# Altium schematic sheet MCU_兼容4IO_HVAC.SchDoc(MCU_兼容4IO_HVAC)
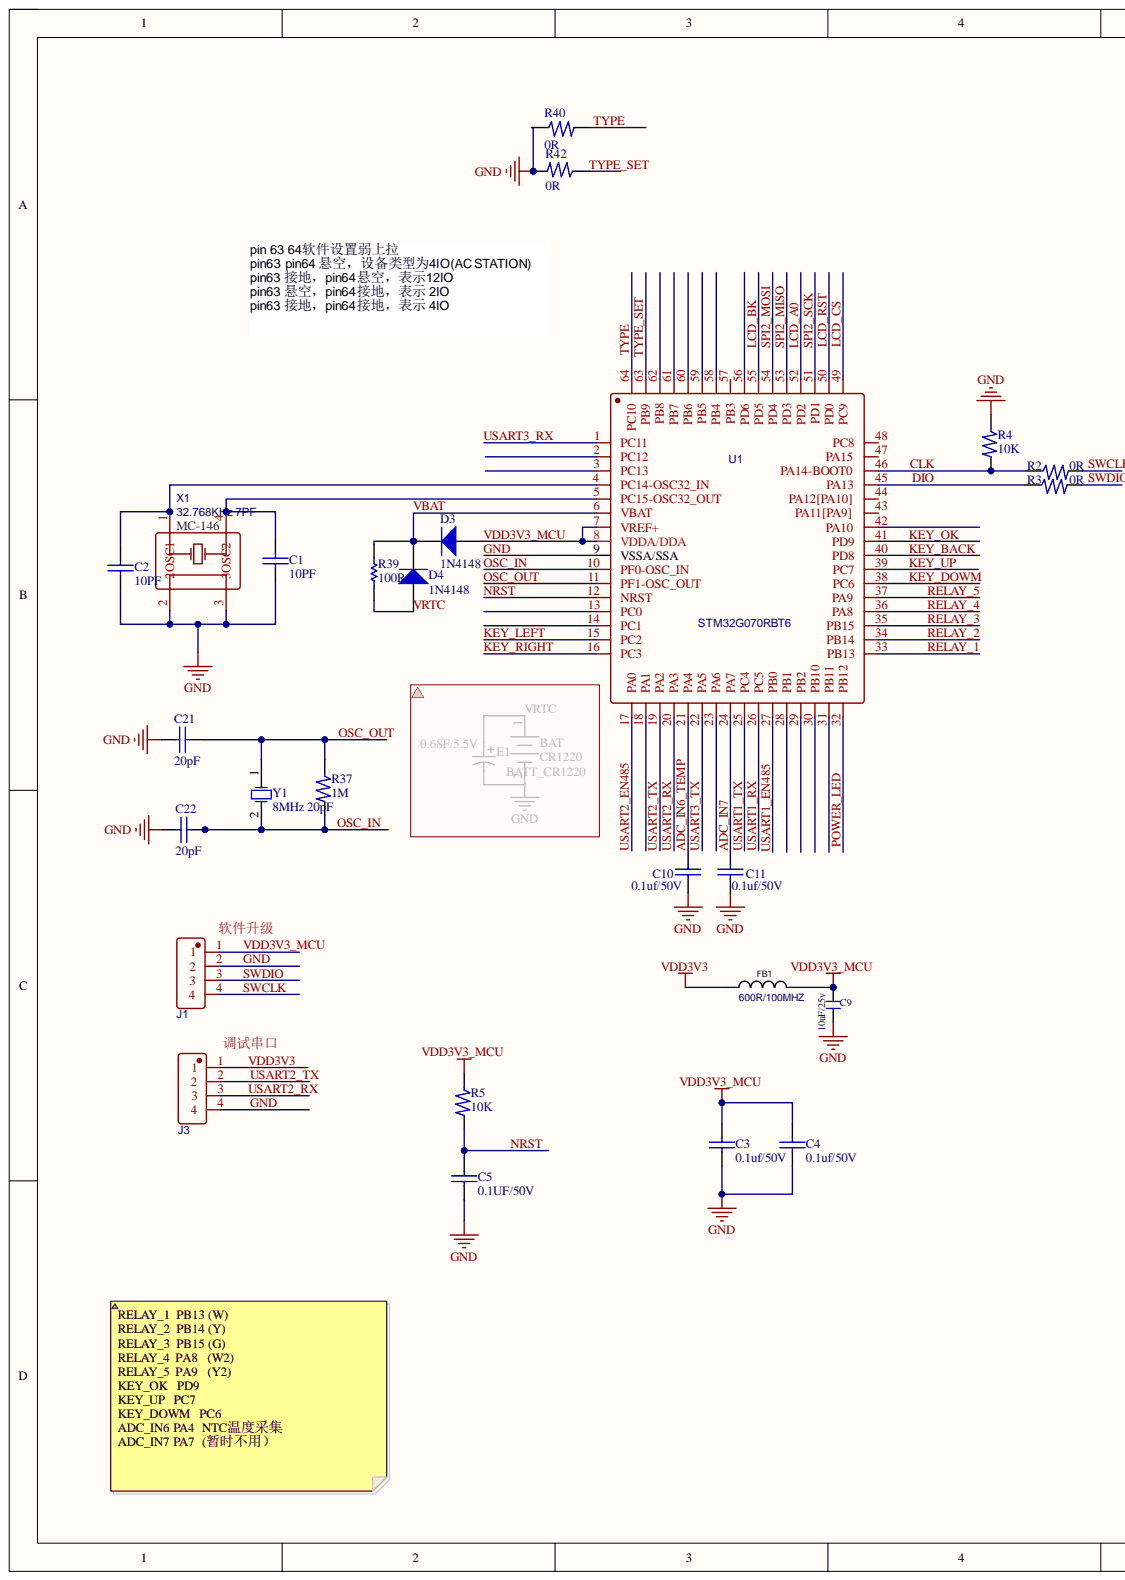
[diagram p0: MCU_兼容4IO_HVAC.SchDoc, left]
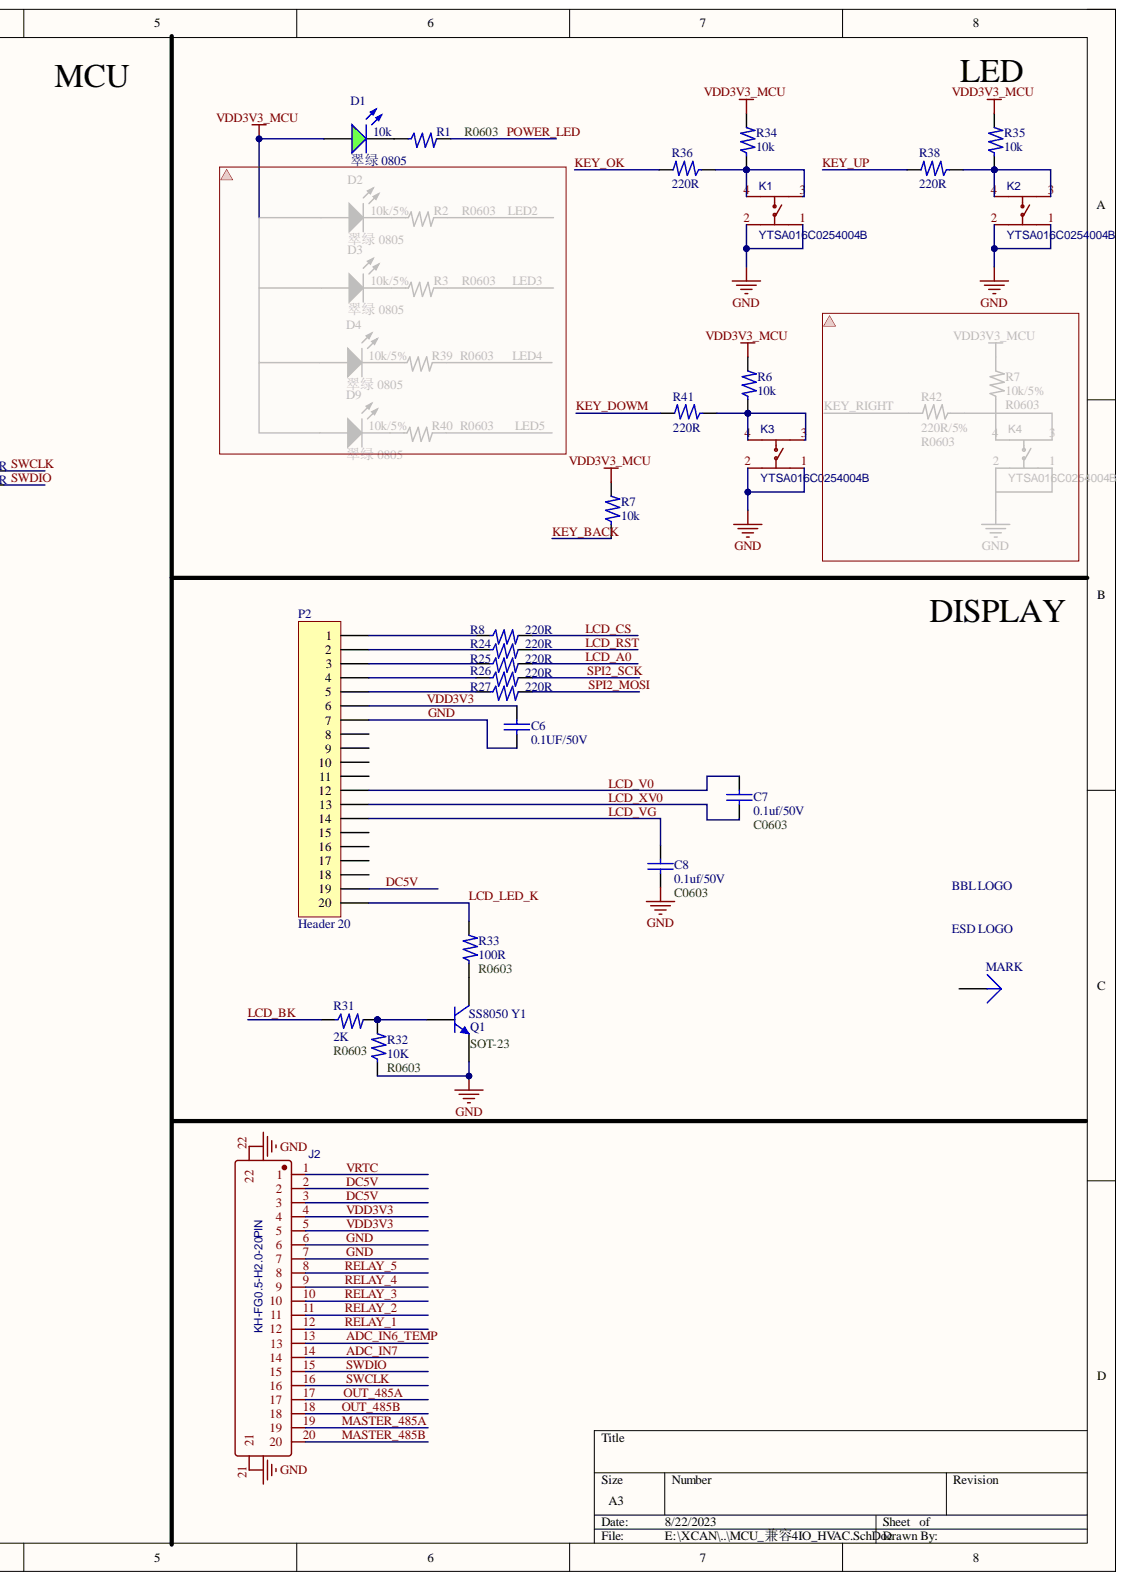
[diagram p1: MCU_兼容4IO_HVAC.SchDoc, right]

## Parts
BBL_LOGO  (no value)  [BBL LOGO]
C1  10PF  [0603_C]
C2  10PF  [0603_C]
C3  0.1uf/50V  [0603_C]
C4  0.1uf/50V  [0603_C]
C5  0.1UF/50V  [0603_C]
C6  0.1UF/50V  [0603_C]
C7  0.1uf/50V  [C0603]
C8  0.1uf/50V  [C0603]
C9  10uF/25v  [0603_c]
C10  0.1uf/50V  [0603_C]
C11  0.1uf/50V  [0603_C]
C21  20pF  [0603_C]
C22  20pF  [0603_C]
D1  翠绿 0805  Package: 0805  [0805_LED_S1]
D3  1N4148  [sod-323]
D4  1N4148  [sod-323]
ESD_LOGO  (no value)  [防静电LOGO]
FB1  600R/100MHZ  [L0603]
J1  Header 4  [CON4-2.0]  (value not drawn)
J2  KH-FG0.5-H2.0-20PIN  [FPC-SMD_KH-FG0.5-H2.0-20PIN]
J3  4PIN2.54  [4PIN2.54]  (value not drawn)
K1  YTSA016C0254004B  [KEY-SMD_4P-L4.2-W3.4-P2.15-LS4.5-TL]
K2  YTSA016C0254004B  [KEY-SMD_4P-L4.2-W3.4-P2.15-LS4.5-TL]
K3  YTSA016C0254004B  [KEY-SMD_4P-L4.2-W3.4-P2.15-LS4.5-TL]
MARK  Plug  [TOP_MARK]  (value not drawn)
P2  Header 20  [LCD1]
Q1  SS8050 Y1  Package: SOT-23  [SOT-23(SOT-23-3)]
R1  10k  [R0603]
R2  0R  [R0603]
R3  0R  [R0603]
R4  10K  [0603_R]
R5  10K  [0603_R]
R6  10k  [0603_R]
R7  10k  [0603_R]
R8  220R  [0603_R]
R24  220R  [0603_R]
R25  220R  [0603_R]
R26  220R  [0603_R]
R27  220R  [0603_R]
R31  2K  [R0603]
R32  10K  [R0603]
R33  100R  [R0603]
R34  10k  [0603_R]
R35  10k  [0603_R]
R36  220R  [0603_R]
R37  1M  [0603_R]
R38  220R  [0603_R]
R39  100R  [0603_R]
R40  0R  [R0603]
R41  220R  [0603_R]
R42  0R  [R0603]
U1  STM32G070RBT6  [LQFP-64_10x10x05P]
X1  32.768KHz 7PF  [MC-146_4P]
Y1  8MHz 20pF  [SMD5032-2P]

## Nets
ADC_IN6_TEMP: C10.1 J2.13 U1.21(PA4)
ADC_IN7: C11.1 J2.14 U1.24(PA7)
CLK: R2.2 R4.1 U1.46(PA14-BOOT0)
DC5V: J2.2 J2.3 P2.19
DIO: R3.2 U1.45(PA13)
GND: C1.2 C2.2 C3.2 C4.2 C5.1 C6.1 C8.2 C9.1 C10.2 C11.2 C21.2 C22.2 J1.2 J2.6 J2.7 J2.21 J2.22 J3.4 K1.1 K1.2 K2.1 K2.2 K3.1 K3.2 P2.7 Q1.2 R4.2 R32.2 R40.2 R42.1 U1.9(VSSA/SSA) X1.2 X1.3
KEY_BACK: R7.2 U1.40(PD8)
KEY_DOWM: R41.1 U1.38(PC6)
KEY_LEFT: U1.15(PC2)
KEY_OK: R36.1 U1.41(PD9)
KEY_RIGHT: U1.16(PC3)
KEY_UP: R38.1 U1.39(PC7)
LCD_A0: R25.1 U1.52(PD2)
LCD_BK: R31.2 U1.55(PD5)
LCD_CS: R8.1 U1.49(PC9)
LCD_LED_K: P2.20 R33.1
LCD_RST: R24.1 U1.50(PD0)
LCD_V0: C7.1 P2.12
LCD_VG: C8.1 P2.14
LCD_XV0: C7.2 P2.13
MASTER_485A: J2.19
MASTER_485B: J2.20
NRST: C5.2 R5.1 U1.12(NRST)
OSC_IN: C22.1 R37.1 U1.10(PF0-OSC_IN) Y1.2
OSC_OUT: C21.1 R37.2 U1.11(PF1-OSC_OUT) Y1.1
OUT_485A: J2.17
OUT_485B: J2.18
POWER_LED: R1.1 U1.32(PB12)
RELAY_1: J2.12 U1.33(PB13)
RELAY_2: J2.11 U1.34(PB14)
RELAY_3: J2.10 U1.35(PB15)
RELAY_4: J2.9 U1.36(PA8)
RELAY_5: J2.8 U1.37(PA9)
SPI2_MISO: U1.53(PD3)
SPI2_MOSI: R27.1 U1.54(PD4)
SPI2_SCK: R26.1 U1.51(PD1)
SWCLK: J1.4 J2.16 R2.1
SWDIO: J1.3 J2.15 R3.1
TYPE: R40.1 U1.64(PC10)
TYPE_SET: R42.2 U1.63(PB9)
USART1_EN485: U1.27(PB0)
USART1_RX: U1.26(PC5)
USART1_TX: U1.25(PC4)
USART2_EN485: U1.17(PA0)
USART2_RX: J3.3 U1.20(PA3)
USART2_TX: J3.2 U1.19(PA2)
USART3_RX: U1.1(PC11)
USART3_TX: U1.22(PA5)
VBAT: D3.2 D4.2 R39.2 U1.6(VBAT)
VDD3V3: C6.2 FB1.1 J2.4 J2.5 J3.1 P2.6
VDD3V3_MCU: C3.1 C4.1 C9.2 D3.1 FB1.2 J1.1 R5.2 R6.1 R7.1 R34.1 R35.1 U1.7(VREF+) U1.8(VDDA/DDA)
VRTC: D4.1 J2.1 R39.1
NetC1_1: C1.1 U1.5(PC15-OSC32_OUT) X1.4(OSC2)
NetC2_1: C2.1 U1.4(PC14-OSC32_IN) X1.1(OSC1)
NetD1_K: D1.K R1.2
NetK1_3: K1.3 K1.4 R34.2 R36.2
NetK2_3: K2.3 K2.4 R35.2 R38.2
NetK3_3: K3.3 K3.4 R6.2 R41.2
NetP2_1: P2.1 R8.2
NetP2_2: P2.2 R24.2
NetP2_3: P2.3 R25.2
NetP2_4: P2.4 R26.2
NetP2_5: P2.5 R27.2
NetQ1_1: Q1.1 R31.1 R32.1
NetQ1_3: Q1.3 R33.2

Not connected: D1 A; MARK 1; P2 8 9 10 11 15 16 17 18; U1 2(PC12) 3(PC13) 13(PC0) 14(PC1) 18(PA1) 23(PA6) 28(PB1) 29(PB2) 30(PB10) 31(PB11) 42(PA10) 43(PA11[PA9]) 44(PA12[PA10]) 47(PA15) 48(PC8) 56(PD6) 57(PB3) 58(PB4) 59(PB5) 60(PB6) 61(PB7) 62(PB8)

## Library data of the parts
BBL_LOGO ESD_LOGO = Component_1: Component Kind: Standard; Library Name: 元件库.SCHLIB
C1-C8 C10 C11 C21 C22 = CAP: Capacitor; Component Kind: Standard
C9 = C: 无极性贴片电容; 版权归属: 源创客; BOM名称: 无极性贴片电容; Component Kind: Standard; Library Name: 3.1   - 电阻-电容-电感.SchLib; 学习资源: www.ooocke.com
D1 = 0805 翠绿: 发光二极管; Component Kind: Standard; ComponentLink1Description: 供应商链接; ComponentLink1URL: http://www.szlcsc.com/product/details_2670.html; Library Name: Miscellaneous Devices LC.IntLib; Supplier: LC; SuppliersPartNumber: C2297
D3 D4 = Diode 1N4148: High Conductance Fast Diode; Code_JEDEC: DO-204-AH; Component Kind: Standard; ComponentLink1Description: Manufacturer Link; ComponentLink1URL: http://www.fairchildsemi.com/; ComponentLink2Description: Datasheet; ComponentLink2URL: http://www.fairchildsemi.com/ds/1N%2F1N4148.pdf; DatasheetVersion: 1997; LatestRevisionDate: 17-Jul-2002; LatestRevisionNote: Re-released for DXP Platform.; Library Name: Miscellaneous Devices.IntLib; PackageDescription: Diode, Axial; Body 3.8 x 1.9 mm (LxDia. max), Lead Dia. 0.53 mm (max); PackageReference: DO-35; Published: 8-Jun-2000; Signal Integrity: 1N4148; Simulation: 1N4148; Publisher: Altium Limited
FB1 = BLM18KG221SN1D: Manufacturer: muRata(村田); Add into BOM: yes; Circuits: 1; Current Rating: 2.2A; DC Resistance: 50mΩ; Impedance @ Frequency: 220Ω@100MHz; Tolerance: ±25%; Component Kind: Standard; Convert to PCB: yes; Datasheet: https://atta.szlcsc.com/upload/public/pdf/source/20180326/C88980_2C536F2328F49D4DFD71B8DED243E2BA.pdf; Device: BLM18KG221SN1D; JLCPCB Part Class: Extended Part; LCSC Part Name: 220Ω@100MHz ±25%; Manufacturer Part: BLM18KG221SN1D; Name: BLM18KG221SN1D; PCB3D: L0603_L1.6-W0.8-H0.5_BEAD; Supplier: LCSC; Supplier Footprint: SMD; Supplier Part: C88980; Symbol: BLM18KG221SN1D
J1 J3 = 4PIN2.54: BOM_Manufacturer: PINREX; BOM_Manufacturer Part: 210-91-04GB01; Contributor: LCSC; BOM_JLCPCB Part Class: Extended Part; BOM_Supplier: LCSC; BOM_Supplier Part: C390680; Component Kind: Standard; Library Name: 元件库.SCHLIB; spicePre: H; spiceSymbolName: 210-91-04GB01
J2 = KH-FG0.5-H2.0-20PIN: BOM_Manufacturer: kinghelm(金航标); Contributor: LCSC; BOM_Manufacturer Part: KH-FG0.5-H2.0-20PIN; BOM_Supplier: LCSC; BOM_Supplier Part: C2797211; Component Kind: Standard; spicePre: C; spiceSymbolName: KH-FG0.5-H2.0-20PIN
K1-K3 = YTSA016C0254004B: BOM_Manufacturer: DGBZ(东莞博众); Contributor: LCSC; BOM_JLCPCB Part Class: Extended Part; BOM_Manufacturer Part: YTSA016C0254004B; BOM_Supplier: LCSC; BOM_Supplier Part: C2764970; Component Kind: Standard; spicePre: S; spiceSymbolName: YTSA016C0254004B
P2 = Header 20: Header, 20-Pin; Component Kind: Standard; LatestRevisionDate: 17-Jul-2002; LatestRevisionNote: Re-released for DXP Platform.; Library Name: Miscellaneous Connectors.IntLib; Signal Integrity: Connector; Publisher: Altium Limited
Q1 = SOT-23 SS8050 Y1: 三极管; Component Kind: Standard; ComponentLink1Description: 供应商链接; ComponentLink1URL: http://www.szlcsc.com/product/details_2507.html; Library Name: Miscellaneous Devices LC.IntLib; Supplier: LC; SuppliersPartNumber: C2150
R1-R8 R24-R27 R31-R38 R40-R42 = 电阻: Component Kind: Standard
R39 = Res1: Resistor; Value: 1K; Component Kind: Standard; LatestRevisionDate: 17-Jul-2002; LatestRevisionNote: Re-released for DXP Platform.; Library Name: Miscellaneous Devices.IntLib; PackageDescription: Axial Device, Thru-Hole; 2 Leads; 0.3 in Pin Spacing; PackageReference: AXIAL-0.3; Published: 8-Jun-2000; Signal Integrity: Res; Simulation: RESISTOR; Publisher: Altium Limited
U1 = STM32G070RBT6: BOM_Manufacturer: STMicroelectronics; Contributor: LCSC; BOM_JLCPCB Part Class: Extended Part; BOM_Manufacturer Part: STM32G070RBT6; BOM_Supplier: LCSC; BOM_Supplier Part: C529340; Component Kind: Standard; spicePre: U; spiceSymbolName: STM32G070RBT6
X1 = 32.768KHz: BOM_Manufacturer: EPSON; BOM_Manufacturer Part: MC-14632.768kHz7.0pF20PPM; Contributor: LCSC; nameAlias: Frequency; SMT Type: Extend; BOM_JLCPCB SMD: Yes; BOM_Supplier: LCSC; BOM_Supplier Part: C94977; Component Kind: Standard; spicePre: X; spiceSymbolName: MC-14632.768kHz7.0pF20PPM
Y1 = SMD-5032_2P 8MHz 20ppm 20pF: 贴片晶振; Component Kind: Standard; ComponentLink1Description: 供应商链接; ComponentLink1URL: http://www.szlcsc.com/product/details_117214.html; Library Name: Miscellaneous Devices LC.IntLib; Package: HC-49SMD; Supplier: LC; SuppliersPartNumber: C115962
MARK = Plug: Component Kind: Standard; Description: Plug; LatestRevisionDate: 17-Jul-2002; LatestRevisionNote: Re-released for DXP Platform.; Library Name: Miscellaneous Connectors.IntLib; Signal Integrity: Connector; Publisher: Altium Limited
